# Altium schematic sheet Fan Control Block.SchDoc
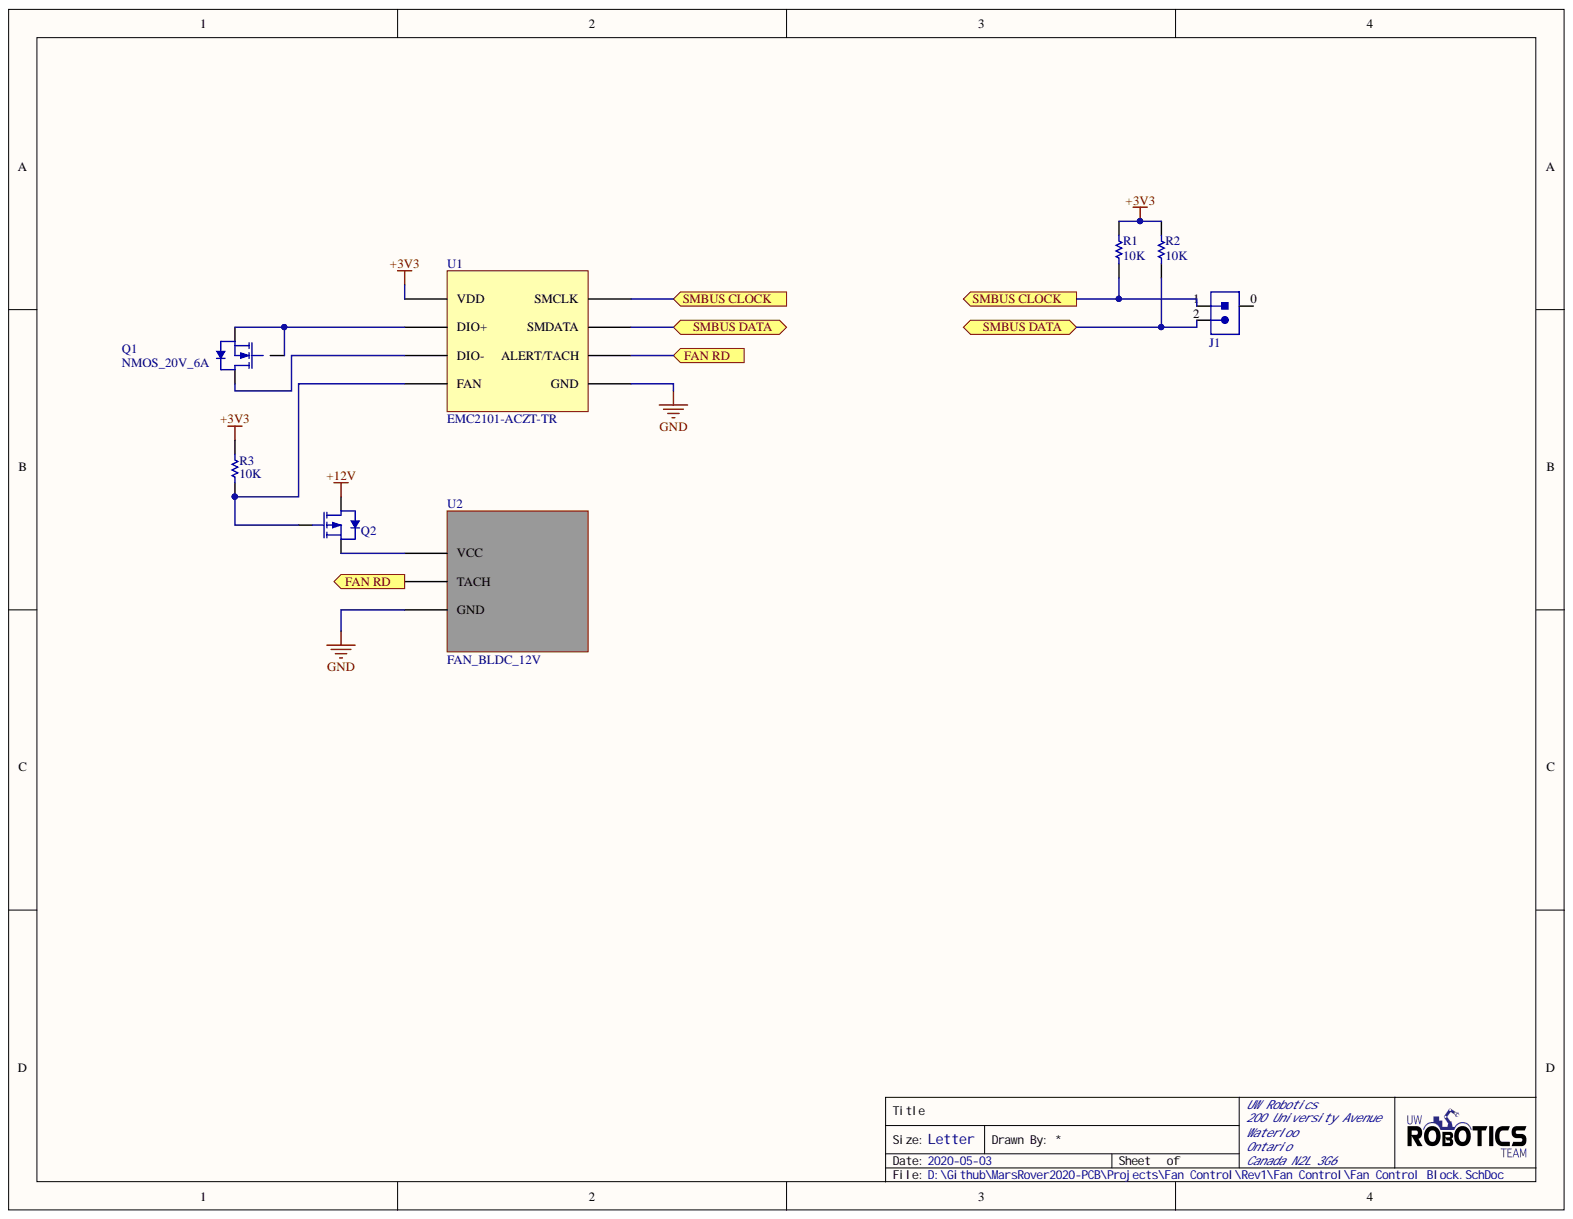
[diagram p0: Fan Control Block.SchDoc]

## Parts
J1  CON_2M_SM4  [JST-B2B-SM4-TB]  (value not drawn)
Q1  NMOS_20V_6A  [NMOS_SOT23-3]
Q2  PMOS_40V_8.4A  [D-PAK]  (value not drawn)
R1  value 10K  [RESC0603(1608)_N]  (hidden comment: RES_10K_0603)
R2  value 10K  [RESC0603(1608)_N]  (hidden comment: RES_10K_0603)
R3  value 10K  [RESC0603(1608)_N]  (hidden comment: RES_10K_0603)
U1  EMC2101-ACZT-TR  [SOIC-8_SG]
U2  FAN_BLDC_12V

## Ports
FAN RD, SMBUS CLOCK, SMBUS DATA

## Nets
+3V3: R1.2 R2.2 R3.1 U1.1(VDD)
+12V: Q2.1
GND: U1.5(GND) U2.3
FAN RD: U1.6(ALERT/TACH) U2.2 ; port FAN RD
SMBUS CLOCK: J1.1 R1.1 U1.8(SMCLK) ; port SMBUS CLOCK
SMBUS DATA: J1.2 R2.1 U1.7(SMDATA) ; port SMBUS DATA
NetQ1_1: Q1.1 Q1.2 U1.2(DIO+)
NetQ1_3: Q1.3 U1.3(DIO-)
NetQ2_2: Q2.2 R3.2 U1.4(FAN)
NetQ2_3: Q2.3 U2.1

Not connected: J1 0

## Library data of the parts
J1 = CON_2M_SM4: CONN HEADER SMD 2POS 2MM; Manufacturer 1: JST; Manufacturer Part Number 1: B2B-PH-SM4-TB(LF)(SN); Max Current Rating: 2A; Max Voltage Rating: 100V; Component Kind: Standard; ComponentLink1Description: https://datasheet.ciiva.com/11748/0900766b80ddea81-11748001.pdf?src-supplier=RSComponents; ComponentLink1URL: https://datasheet.ciiva.com/11748/0900766b80ddea81-11748001.pdf?src-supplier=RSComponents; ComponentLink2Description: https://datasheet.ciiva.com/16216/b2b-ph-sm4-tblfsn-16216102.pdf?src-supplier=Future+Electronics; ComponentLink2URL: https://datasheet.ciiva.com/16216/b2b-ph-sm4-tblfsn-16216102.pdf?src-supplier=Future+Electronics; ComponentLink3Description: https://datasheet.ciiva.com/8052/80567-8052023.pdf?src-supplier=Element14; ComponentLink3URL: https://datasheet.ciiva.com/8052/80567-8052023.pdf?src-supplier=Element14; ComponentLink4Description: https://datasheet.ciiva.com/712/eph-712504.pdf?src-supplier=Digi-Key; ComponentLink4URL: https://datasheet.ciiva.com/712/eph-712504.pdf?src-supplier=Digi-Key; ComponentLink5Description: https://datasheet.ciiva.com/712/eph-712505.pdf?src-supplier=Digi-Key; ComponentLink5URL: https://datasheet.ciiva.com/712/eph-712505.pdf?src-supplier=Digi-Key; ComponentLink6Description: https://datasheet.ciiva.com/712/eph-712506.pdf?src-supplier=Digi-Key; ComponentLink6URL: https://datasheet.ciiva.com/712/eph-712506.pdf?src-supplier=Digi-Key; Mount: Surface Mount; Pitch: 2mm; Supplier 1: Digi-Key; Supplier Part Number 1: 455-1734-1-ND; Library Name: UWRT_Schematic_Library_2020.SchLib
Q1 = NMOS_20V_6A: MOSFET N-CH 20V 6A SOT23; Manufacturer 1: Alpha & Omega; Manufacturer Part Number 1: AO3420; Component Kind: Standard; ComponentLink1Description: Datasheet; ComponentLink1URL: http://aosmd.com/res/data_sheets/AO3420.pdf; Mount: Surface Mount; Package: TO-236-3, SC-59, SOT-23-3; Simulation: NMOS; Supplier 1: Digi-Key; Supplier Part Number 1: 785-1014-1-ND; Library Name: UWRT_Schematic_Library_2020.SchLib
Q2 = PMOS_40V_8.4A: MOSFET P-CH 40V 8.4A D-PAK; Manufacturer 1: ON Semiconductor / Fairchild; Manufacturer Part Number 1: FDD4685; Current Rating: -8.4A; Drain to Source Breakdown Voltage: -40V; Drain to Source Resistance: 27mR; Element Configuration: Single; Fall Time: 14ns; Gate to Source Voltage (Vgs): 20V; Input Capacitance: 2.38nF; Max Power Dissipation: 69W; Nominal Vgs: -1.6V; Number of Elements: 1; Rise Time: 15ns; Turn-Off Delay Time: 34ns; Turn-On Delay Time: 8ns; Case/Package: TO-252; Component Kind: Standard; ComponentLink1Description: https://datasheet.ciiva.com/6382/fdd4685-6382336.pdf?src-supplier=Digi-Key; ComponentLink1URL: https://datasheet.ciiva.com/6382/fdd4685-6382336.pdf?src-supplier=Digi-Key; ComponentLink2Description: https://datasheet.ciiva.com/22700/298848-22700881.pdf?src-supplier=Element14; ComponentLink2URL: https://datasheet.ciiva.com/22700/298848-22700881.pdf?src-supplier=Element14; ComponentLink3Description: https://datasheet.ciiva.com/4439/fdd4685-94891-4439978.pdf?src-supplier=Mouser; ComponentLink3URL: https://datasheet.ciiva.com/4439/fdd4685-94891-4439978.pdf?src-supplier=Mouser; ComponentLink4Description: https://datasheet.ciiva.com/7967/298848-7967879.pdf?src-supplier=Element14; ComponentLink4URL: https://datasheet.ciiva.com/7967/298848-7967879.pdf?src-supplier=Element14; ComponentLink5Description: https://datasheet.ciiva.com/26144/fdd4685-26144727.pdf?src-supplier=Avnet+Europe; ComponentLink5URL: https://datasheet.ciiva.com/26144/fdd4685-26144727.pdf?src-supplier=Avnet+Europe; ComponentLink6Description: https://datasheet.ciiva.com/22746/1930081-22746458.pdf?src-supplier=Element14; ComponentLink6URL: https://datasheet.ciiva.com/22746/1930081-22746458.pdf?src-supplier=Element14; ComponentLink7Description: https://datasheet.ciiva.com/22655/1930081-22655292.pdf?src-supplier=Element14; ComponentLink7URL: https://datasheet.ciiva.com/22655/1930081-22655292.pdf?src-supplier=Element14; ComponentLink8Description: https://datasheet.ciiva.com/16742/fdd4685-16742918.pdf?src-supplier=Future+Electronics; ComponentLink8URL: https://datasheet.ciiva.com/16742/fdd4685-16742918.pdf?src-supplier=Future+Electronics; ComponentLink9Description: https://datasheet.ciiva.com/11175/fdd4685-11175308.pdf?src-supplier=Avnet; ComponentLink9URL: https://datasheet.ciiva.com/11175/fdd4685-11175308.pdf?src-supplier=Avnet; Contact Plating: Tin,Matte; Continuous Drain Current (ID): 8.4A; Drain to Source Voltage (Vdss): 40V; Dual Supply Voltage: -40V; Height: 2.39mm; Lead Free: Lead Free; Length: 6.73mm; Max Operating Temperature: 150°C; Min Operating Temperature: -55°C; Mount: Surface Mount; Number of Pins: 3; On-State Resistance: 27MR; Package Quantity: 2500; Packaging: Tape and Reel; Power Dissipation: 69W; Pricing 1: 1=1.03000, 10=0.92200, 25=0.87560, 100=0.65670, 250=0.65040, 500=0.55660, 1000=0.45342 (USD); Radiation Hardening: No; Rds On Max: 27mR; REACH SVHC: No SVHC; RoHS: Yes; Stock 1: 9732; Supplier 1: Digi-Key; Supplier Part Number 1: FDD4685CT-ND; Termination: SMD/SMT; Threshold Voltage: -1.6V; Voltage Rating (DC): -40V; Weight: 0.26037g; Width: 6.22mm; Library Name: UWRT_Schematic_Library_2020.SchLib
R1-R3 = RES_10K_0603: RES SMD 10K OHM 5% 1/10W 0603; Manufacturer 1: Yageo; Manufacturer Part Number 1: RC0603JR-0710KL; Component Kind: Standard; Dimensions: 0.063" L x 0.031" W (1.60mm x 0.80mm); Mount: Surface Mount; Package: 0603 (1608 Metric); Signal Integrity: Res; Simulation: RESISTOR; Supplier 1: Digi-Key; Supplier Part Number 1: 311-10KGRCT-ND; Tolerance: 5%; Library Name: UWRT_Schematic_Library_2020.SchLib
U1 = EMC2101-ACZT-TR: SMBUS FAN CONTROL WITH TEMP MONITORING; Manufacturer 1: Microchip Technology; Component Kind: Standard; Manufacturer Part Number 1: EMC2101-ACZT-TR; Mount: Surface Mount; Supplier 1: Digi-Key; Supplier Part Number 1: EMC2101-ACZT-CT-ND; Library Name: UWRT_Schematic_Library_2020.SchLib
U2 = FAN_BLDC_12V: GENERIC 12V BLDC FAN WITH INTEGRATED DRIVER AND TACHOMETER OUTPUT; Component Kind: Standard; Library Name: UWRT_Schematic_Library_2020.SchLib
